# Altium schematic sheet BBB2_P9.SchDoc
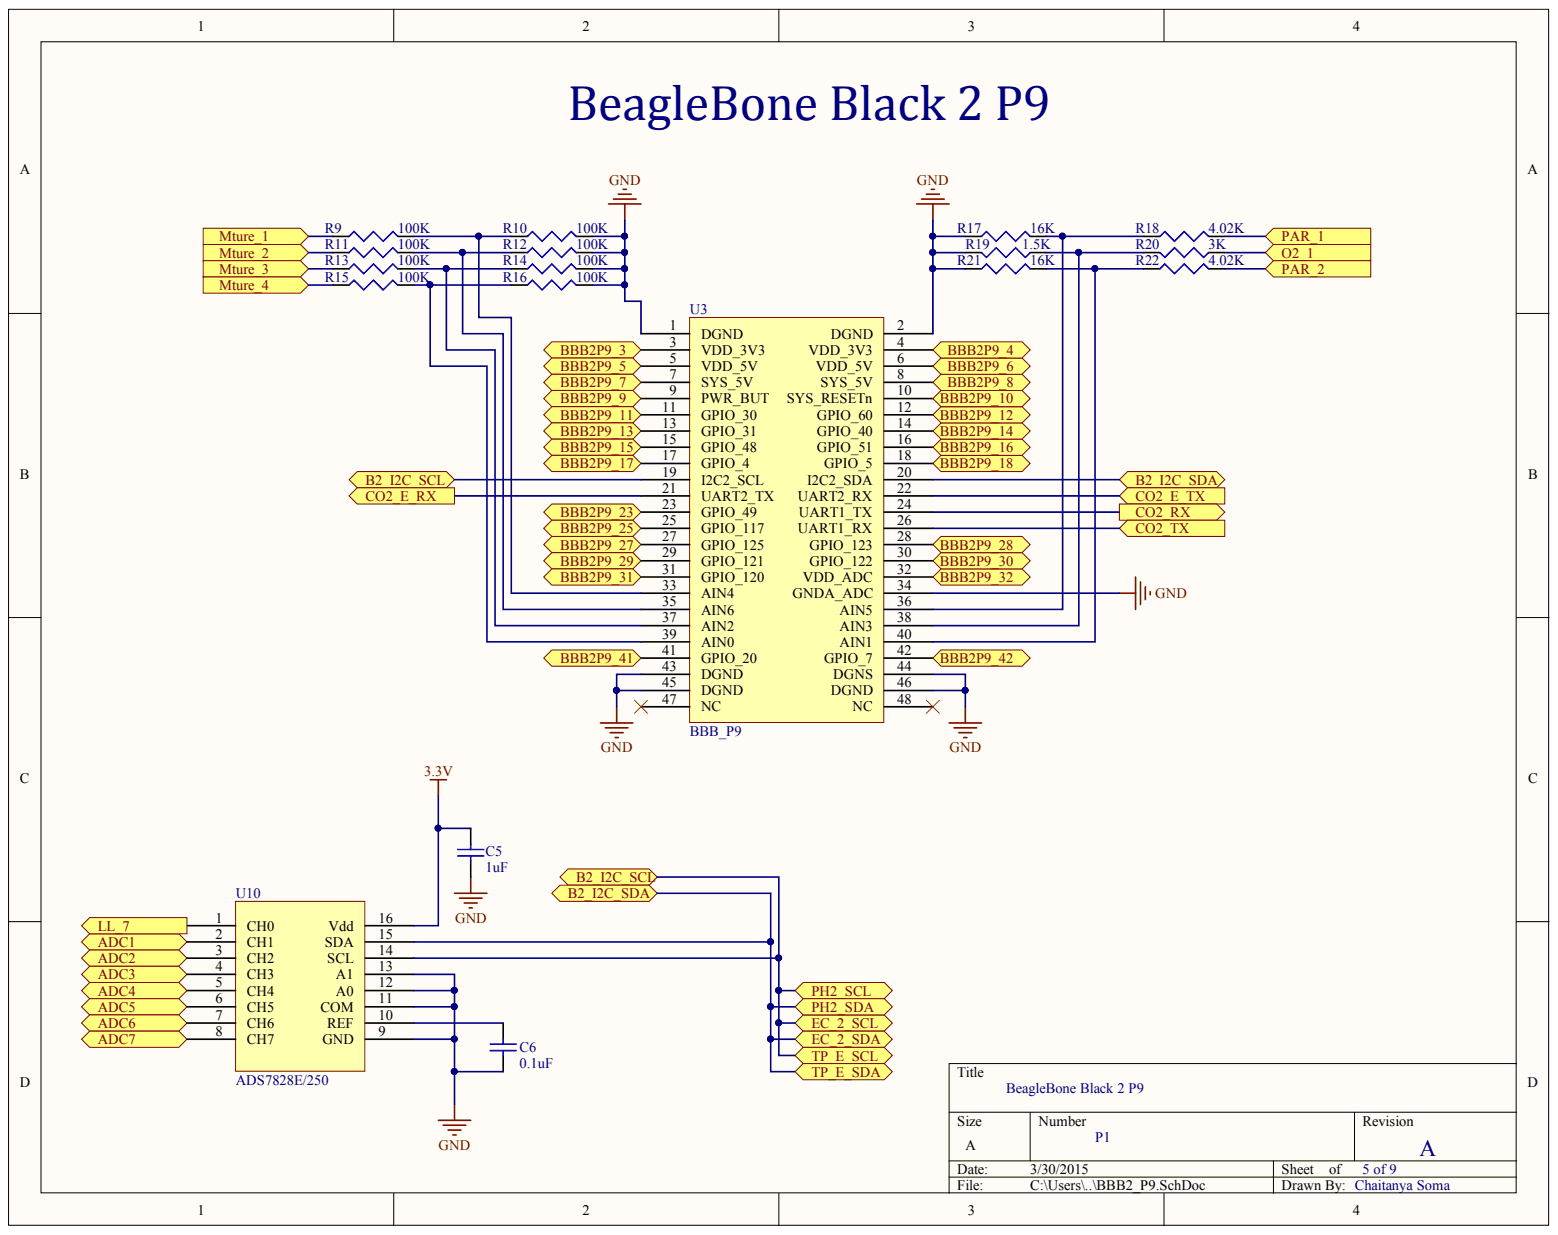
[diagram p0: BBB2_P9.SchDoc]

## Parts
C5  value 1uF  [1608[0603]]  (hidden comment: Cap Semi)
C6  value 0.1uF  [1608[0603]]  (hidden comment: Cap Semi)
R9  value 100K  [J1-0603]  (hidden comment: Res3)
R10  value 100K  [J1-0603]  (hidden comment: Res3)
R11  value 100K  [J1-0603]  (hidden comment: Res3)
R12  value 100K  [J1-0603]  (hidden comment: Res3)
R13  value 100K  [J1-0603]  (hidden comment: Res3)
R14  value 100K  [J1-0603]  (hidden comment: Res3)
R15  value 100K  [J1-0603]  (hidden comment: Res3)
R16  value 100K  [J1-0603]  (hidden comment: Res3)
R17  value 16K  [J1-0603]  (hidden comment: Res3)
R18  value 4.02K  [J1-0603]  (hidden comment: Res3)
R19  value 1.5K  [J1-0603]  (hidden comment: Res3)
R20  value 3K  [J1-0603]  (hidden comment: Res3)
R21  value 16K  [J1-0603]  (hidden comment: Res3)
R22  value 4.02K  [J1-0603]  (hidden comment: Res3)
U3  BBB_P9  [BeagleBoneBlackP9]
U10  ADS7828E/250  [ADS7828E/250]

## Ports
ADC1, ADC2, ADC3, ADC4, ADC5, ADC6, ADC7, B2_I2C_SCL, B2_I2C_SDA, BBB2P9_3, BBB2P9_4, BBB2P9_5, BBB2P9_6, BBB2P9_7, BBB2P9_8, BBB2P9_9, BBB2P9_10, BBB2P9_11, BBB2P9_12, BBB2P9_13, BBB2P9_14, BBB2P9_15, BBB2P9_16, BBB2P9_17, BBB2P9_18, BBB2P9_23, BBB2P9_25, BBB2P9_27, BBB2P9_28, BBB2P9_29, BBB2P9_30, BBB2P9_31, BBB2P9_32, BBB2P9_41, BBB2P9_42, CO2_E_RX, CO2_E_TX, CO2_RX, CO2_TX, EC_2_SCL, EC_2_SDA, LL_7, MTURE_1, MTURE_2, MTURE_3, MTURE_4, O2_1, PAR_1, PAR_2, PH2_SCL, PH2_SDA, TP_E_SCL, TP_E_SDA

## Nets
3.3V: C5.1 U10.16(Vdd)
GND: C5.2 C6.2 R10.2 R12.2 R14.2 R16.2 R17.1 R19.2 R21.1 U3.1(DGND) U3.2(DGND) U3.34(GNDA_ADC) U3.43(DGND) U3.44(DGNS) U3.45(DGND) U3.46(DGND) U10.9(GND) U10.11(COM) U10.12(A0) U10.13(A1)
ADC1: U10.2(CH1) ; port ADC1
ADC2: U10.3(CH2) ; port ADC2
ADC3: U10.4(CH3) ; port ADC3
ADC4: U10.5(CH4) ; port ADC4
ADC5: U10.6(CH5) ; port ADC5
ADC6: U10.7(CH6) ; port ADC6
ADC7: U10.8(CH7) ; port ADC7
B2_I2C_SCL: U3.19(I2C2_SCL) U10.14(SCL) ; port B2_I2C_SCL, port EC_2_SCL, port PH2_SCL, port TP_E_SCL
B2_I2C_SDA: U3.20(I2C2_SDA) U10.15(SDA) ; port B2_I2C_SDA, port EC_2_SDA, port PH2_SDA, port TP_E_SDA
BBB2P9_3: U3.3(VDD_3V3) ; port BBB2P9_3
BBB2P9_4: U3.4(VDD_3V3) ; port BBB2P9_4
BBB2P9_5: U3.5(VDD_5V) ; port BBB2P9_5
BBB2P9_6: U3.6(VDD_5V) ; port BBB2P9_6
BBB2P9_7: U3.7(SYS_5V) ; port BBB2P9_7
BBB2P9_8: U3.8(SYS_5V) ; port BBB2P9_8
BBB2P9_9: U3.9(PWR_BUT) ; port BBB2P9_9
BBB2P9_10: U3.10(SYS_RESETn) ; port BBB2P9_10
BBB2P9_11: U3.11(GPIO_30) ; port BBB2P9_11
BBB2P9_12: U3.12(GPIO_60) ; port BBB2P9_12
BBB2P9_13: U3.13(GPIO_31) ; port BBB2P9_13
BBB2P9_14: U3.14(GPIO_40) ; port BBB2P9_14
BBB2P9_15: U3.15(GPIO_48) ; port BBB2P9_15
BBB2P9_16: U3.16(GPIO_51) ; port BBB2P9_16
BBB2P9_17: U3.17(GPIO_4) ; port BBB2P9_17
BBB2P9_18: U3.18(GPIO_5) ; port BBB2P9_18
BBB2P9_23: U3.23(GPIO_49) ; port BBB2P9_23
BBB2P9_25: U3.25(GPIO_117) ; port BBB2P9_25
BBB2P9_27: U3.27(GPIO_125) ; port BBB2P9_27
BBB2P9_28: U3.28(GPIO_123) ; port BBB2P9_28
BBB2P9_29: U3.29(GPIO_121) ; port BBB2P9_29
BBB2P9_30: U3.30(GPIO_122) ; port BBB2P9_30
BBB2P9_31: U3.31(GPIO_120) ; port BBB2P9_31
BBB2P9_32: U3.32(VDD_ADC) ; port BBB2P9_32
BBB2P9_41: U3.41(GPIO_20) ; port BBB2P9_41
BBB2P9_42: U3.42(GPIO_7) ; port BBB2P9_42
CO2_E_RX: U3.21(UART2_TX) ; port CO2_E_RX
CO2_E_TX: U3.22(UART2_RX) ; port CO2_E_TX
CO2_RX: U3.24(UART1_TX) ; port CO2_RX
CO2_TX: U3.26(UART1_RX) ; port CO2_TX
LL_7: U10.1(CH0) ; port LL_7
O2_1: R20.1 ; port O2_1
PAR_1: R18.2 ; port PAR_1
PAR_2: R22.2 ; port PAR_2
NetC6_1: C6.1 U10.10(REF)
NetR9_1: R9.1 ; port MTURE_1
NetR9_2: R9.2 R10.1 U3.33(AIN4)
NetR11_1: R11.1 ; port MTURE_2
NetR11_2: R11.2 R12.1 U3.35(AIN6)
NetR13_1: R13.1 ; port MTURE_3
NetR13_2: R13.2 R14.1 U3.37(AIN2)
NetR15_1: R15.1 ; port MTURE_4
NetR15_2: R15.2 R16.1 U3.39(AIN0)
NetR17_2: R17.2 R18.1 U3.36(AIN5)
NetR19_1: R19.1 R20.2 U3.38(AIN3)
NetR21_2: R21.2 R22.1 U3.40(AIN1)

Not connected: U3 47(NC) 48(NC)

## Library data of the parts
C5 = Cap Semi: Capacitor (Semiconductor SIM Model); Component Cost: 0.10000; Component Link Descriptions: CAP CER 1UF 25V 10% X5R 0603; Component Kind: Standard; Component Link URL: http://www.digikey.com/product-detail/en/GRM188R61E105KA12D/490-3897-1-ND/965939; Digikey PN: 490-3897-1-ND; LatestRevisionDate: 29-May-2009; LatestRevisionNote: IPC-7351 Footprint Added.; Library Name: Miscellaneous Devices.IntLib; PackageDescription: Chip Capacitor; PackageReference: C1206; Published: 8-Jun-2000; Signal Integrity: Cap Semi; Simulation: CAP; Publisher: Altium Limited
C6 = Cap Semi: Capacitor (Semiconductor SIM Model); Component Cost: 0.10000; Component Link Descriptions: CAP CER 0.1UF 25V 10% X7R 0603; Component Kind: Standard; Component Link URL: http://www.digikey.com/product-detail/en/GRM188R71E104KA01D/490-1524-1-ND/587865; Digikey PN: 490-1524-1-ND; LatestRevisionDate: 29-May-2009; LatestRevisionNote: IPC-7351 Footprint Added.; Library Name: Miscellaneous Devices.IntLib; PackageDescription: Chip Capacitor; PackageReference: C1206; Published: 8-Jun-2000; Signal Integrity: Cap Semi; Simulation: CAP; Publisher: Altium Limited
R9-R16 = Res3: Resistor; Component Cost: 0.29000; Component Link Descriptions: RES SMD 100K OHM 1% 1/4W 0603; Component Kind: Standard; Component Link URL: http://www.digikey.com/product-detail/en/ERJ-PA3F1003V/P100KBYCT-ND/5036116; Digikey PN: P100KBYCT-ND; LatestRevisionDate: 29-May-2009; LatestRevisionNote: IPC-7351 Footprint Added.; Library Name: Miscellaneous Devices.IntLib; PackageDescription: Chip Resistor; PackageReference: J1-0603; Published: 8-Jun-2000; Signal Integrity: Res; Simulation: RESISTOR; Publisher: Altium Limited
R17 R21 = Res3: Resistor; Component Cost: 0.20000; Component Link Descriptions: RES SMD 16K OHM 5% 1/4W 0603; Component Kind: Standard; Component Link URL: http://www.digikey.com/product-detail/en/ERJ-PA3J163V/P16KBZCT-ND/5036263; Digikey PN: P16KBZCT-ND; LatestRevisionDate: 29-May-2009; LatestRevisionNote: IPC-7351 Footprint Added.; Library Name: Miscellaneous Devices.IntLib; PackageDescription: Chip Resistor; PackageReference: J1-0603; Published: 8-Jun-2000; Signal Integrity: Res; Simulation: RESISTOR; Publisher: Altium Limited
R18 R22 = Res3: Resistor; Component Cost: 0.10000; Component Link Descriptions: RES SMD 4.02K OHM 1% 1/8W 0603; Component Kind: Standard; Component Link URL: http://www.digikey.com/product-detail/en/RNCP0603FTD4K02/RNCP0603FTD4K02CT-ND/2240463; Digikey PN: RNCP0603FTD4K02CT-ND; LatestRevisionDate: 29-May-2009; LatestRevisionNote: IPC-7351 Footprint Added.; Library Name: Miscellaneous Devices.IntLib; PackageDescription: Chip Resistor; PackageReference: J1-0603; Published: 8-Jun-2000; Signal Integrity: Res; Simulation: RESISTOR; Publisher: Altium Limited
R19 = Res3: Resistor; Component Cost: 0.10000; Component Link Descriptions: RES SMD 1.5K OHM 1% 1/10W 0603; Component Kind: Standard; Component Link URL: http://www.digikey.com/product-detail/en/RC1608F152CS/1276-4660-1-ND/3967632; Digikey PN: 1276-4660-1-ND; LatestRevisionDate: 29-May-2009; LatestRevisionNote: IPC-7351 Footprint Added.; Library Name: Miscellaneous Devices.IntLib; PackageDescription: Chip Resistor; PackageReference: J1-0603; Published: 8-Jun-2000; Signal Integrity: Res; Simulation: RESISTOR; Publisher: Altium Limited
R20 = Res3: Resistor; Component Cost: 0.10000; Component Link Descriptions: RES SMD 3K OHM 1% 1/10W 0603; Component Kind: Standard; Component Link URL: http://www.digikey.com/product-detail/en/ERJ-3EKF3001V/P3.00KHCT-ND/1746757; Digikey PN: P3.00KHCT-ND; LatestRevisionDate: 29-May-2009; LatestRevisionNote: IPC-7351 Footprint Added.; Library Name: Miscellaneous Devices.IntLib; PackageDescription: Chip Resistor; PackageReference: J1-0603; Published: 8-Jun-2000; Signal Integrity: Res; Simulation: RESISTOR; Publisher: Altium Limited
U3 = BBB_P9: Beagle Bone Black P9; Component Cost: 2.81000; Component Link Descriptions: CONN HEADER FMAL 46PS.1" DL GOLD; Component Kind: Standard; Component Link URL: http://www.digikey.com/product-detail/en/PPPC232LFBN-RC/S7126-ND/810262; Digikey PN: S7126-ND; Library Name: Power.SchLib
U10 = ADS7828E/250: Component Cost: 8.94; Component Link Descriptions: IC ADC 12BIT 50KSPS 8CH 16TSSOP; Component Kind: Standard; Component Link URL: http://www.digikey.com/product-detail/en/ADS7828E%2F250/296-12402-1-ND/417222; Digikey PN: 296-12402-1-ND; Library Name: Power.SchLib
